# Altium schematic sheet LTC3350.SchDoc(LTC3350) (continued)

## Library data of the parts
C1 C13 C14 C18 C20 C21 = CAP 0603 100nF 50V: Ceramic Capacitor, Multilayer, Ceramic, 50V, 10% +Tol, 10% -Tol, X7R, 15% TC, 0.1uF, Surface Mount, 0603; Manufacturer: Samsung; Manufacturer Part Number: CL10B104KB8NNNC; Multilayer: Yes; Capacitor Type: Ceramic; Case/Package: 0603; Category: Ceramic Capacitors; Component Kind: Standard; ComponentLink1Description: https://datasheet.ciiva.com:443/pdfs/VipMasterIC/IC/SEMS/SEMS-S-A0008384515/SEMS-S-A0008873300-1.pdf?src-supplier=IHS+Markit; ComponentLink1URL: https://datasheet.ciiva.com:443/pdfs/VipMasterIC/IC/SEMS/SEMS-S-A0008384515/SEMS-S-A0008873300-1.pdf?src-supplier=IHS+Markit; ComponentLink2Description: https://datasheet.ciiva.com/25803/cl10b104kb8nnnc-25803393.pdf?src-supplier=Digikey; ComponentLink2URL: https://datasheet.ciiva.com/25803/cl10b104kb8nnnc-25803393.pdf?src-supplier=Digikey; ComponentLink3Description: https://datasheet.ciiva.com/26838/getdatasheetpartid-89097-26838411.pdf?src-supplier=Verical; ComponentLink3URL: https://datasheet.ciiva.com/26838/getdatasheetpartid-89097-26838411.pdf?src-supplier=Verical; ComponentLink4Description: https://datasheet.ciiva.com/29198/456651-da-01-en-vs-kondensator-0605-29198839.pdf?src-supplier=Conrad; ComponentLink4URL: https://datasheet.ciiva.com/29198/456651-da-01-en-vs-kondensator-0605-29198839.pdf?src-supplier=Conrad; Dielectric Material: Ceramic; Height: 0.9mm; JESD-609 Code: e3; Length: 1.6mm; Library Name: Symbols.SchLib; Max Operating Temperature: 125°C; Min Operating Temperature: -55°C; Mounting Technology: Surface Mount; Package Shape: Rectangular; Package Style: SMTMeter; Packaging: Tape and Reel; Pins: 2; Pricing: 1=0.1, 10=0.026, 50=0.0146, 100=0.0114, 500=0.00856, 1000=0.00672, 4000=0.00562, 8000=0.00513, 12000=0.00489, 28000=0.00464, 100000=0.00336, 200000=0.00325, 400000=0.00306 (USD); Rated DC Voltage (URdc): 50V; Reach SVHC Compliant: Yes; RoHS: Yes; RoHS Compliant: Yes; Stock: 6712361; Supplier: Digikey; Supplier Part Number: 1276-1000-1-ND; Temperature Characteristics Code: X7R; Terminal Finish: Nickel; Termination: Wraparound; Tolerance  (fill in as +-): 10%; Tolerance (fill in as +-): 10%; Value: 100nF; Width: 0.8mm
C2 C3 C9 = CAP 0805 1uF 50V: Ceramic Capacitor, Multilayer, Ceramic, 50V, 10% +Tol, 10% -Tol, X7R, 15% TC, 1uF, Surface Mount, 0805; Manufacturer: Samsung; Manufacturer Part Number: CL21B105KBFNNNG; Multilayer: Yes; Capacitor Type: Ceramic; Case/Package: 0805; Category: Ceramic Capacitors; Component Kind: Standard; ComponentLink1Description: https://datasheet.ciiva.com:443/pdfs/VipMasterIC/IC/SEMS/SEMS-S-A0008523115/SEMS-S-A0008523251-1.pdf?src-supplier=IHS+Markit; ComponentLink1URL: https://datasheet.ciiva.com:443/pdfs/VipMasterIC/IC/SEMS/SEMS-S-A0008523115/SEMS-S-A0008523251-1.pdf?src-supplier=IHS+Markit; ComponentLink2Description: https://datasheet.ciiva.com/25733/cl21b105kbfnnng-25733278.pdf?src-supplier=Digikey; ComponentLink2URL: https://datasheet.ciiva.com/25733/cl21b105kbfnnng-25733278.pdf?src-supplier=Digikey; ComponentLink3Description: https://datasheet.ciiva.com/29199/455898-da-01-en-vs-kondensator-0805-29199201.pdf?src-supplier=Conrad; ComponentLink3URL: https://datasheet.ciiva.com/29199/455898-da-01-en-vs-kondensator-0805-29199201.pdf?src-supplier=Conrad; ComponentLink4Description: https://datasheet.ciiva.com/26310/mlcc-catalogdownload2013-eng-26310125.pdf?src-supplier=Distrelec; ComponentLink4URL: https://datasheet.ciiva.com/26310/mlcc-catalogdownload2013-eng-26310125.pdf?src-supplier=Distrelec; ComponentLink5Description: https://datasheet.ciiva.com/16428/cl05b104kq5nnnc-16428872.pdf?src-supplier=Future+Electronics; ComponentLink5URL: https://datasheet.ciiva.com/16428/cl05b104kq5nnnc-16428872.pdf?src-supplier=Future+Electronics; Dielectric Material: Ceramic; Height: 1.35mm; JESD-609 Code: e3; Length: 2mm; Library Name: Symbols.SchLib; Max Operating Temperature: 125°C; Min Operating Temperature: -55°C; Mounting Technology: Surface Mount; Package Shape: Rectangular; Package Style: SMTMeter; Packaging: Tape and Reel; Pins: 2; Pricing: 1=0.11, 10=0.075, 50=0.045, 100=0.0354, 500=0.02616, 1000=0.02165, 3000=0.02015, 6000=0.01844, 9000=0.01715, 15000=0.01629, 30000=0.01543, 75000=0.01415, 150000=0.0114, 300000=0.01072 (USD); Rated DC Voltage (URdc): 50V; Reach SVHC Compliant: Yes; RoHS: Yes; RoHS Compliant: Yes; Stock: 1165093; Supplier: Digikey; Supplier Part Number: 1276-6470-1-ND; Temperature Characteristics Code: X7R; Terminal Finish: Nickel; Termination: Wraparound; Tolerance  (fill in as +-): 10%; Tolerance (fill in as +-): 10%; Value: 1uF; Width: 1.25mm
C4-C7 C10-C12 = CAP 1206 10uF 1%: Ceramic Capacitor, Multilayer, Ceramic, 50V, 10% +Tol, 10% -Tol, X7R, 15% TC, 10uF, Surface Mount, 1206; Manufacturer: Samsung; Manufacturer Part Number: CL31B106KBHNNNE; Multilayer: Yes; Capacitor Type: Ceramic; Case/Package: 1206; Category: Ceramic Capacitors; Component Kind: Standard; ComponentLink1Description: https://datasheet.ciiva.com:443/pdfs/VipMasterIC/IC/SEMS/SEMS-S-A0008524842/SEMS-S-A0008524770-1.pdf?src-supplier=IHS+Markit; ComponentLink1URL: https://datasheet.ciiva.com:443/pdfs/VipMasterIC/IC/SEMS/SEMS-S-A0008524842/SEMS-S-A0008524770-1.pdf?src-supplier=IHS+Markit; ComponentLink2Description: https://datasheet.octopart.com/CL31B106KBHNNNE-Samsung-Electro-Mechanics-datasheet-149066874.pdf?src-supplier=Future+Electronics; ComponentLink2URL: https://datasheet.octopart.com/CL31B106KBHNNNE-Samsung-Electro-Mechanics-datasheet-149066874.pdf?src-supplier=Future+Electronics; ComponentLink3Description: http://www.samsungsem.com/kr/support/product-search/mlcc/CL31B106KBHNNNE.jsp?src-supplier=Digikey; ComponentLink3URL: http://www.samsungsem.com/kr/support/product-search/mlcc/CL31B106KBHNNNE.jsp?src-supplier=Digikey; ComponentLink4Description: https://datasheet.octopart.com/CL31B106KBHNNNE-Samsung-Electro-Mechanics-datasheet-110617700.pdf?src-supplier=LCSC; ComponentLink4URL: https://datasheet.octopart.com/CL31B106KBHNNNE-Samsung-Electro-Mechanics-datasheet-110617700.pdf?src-supplier=LCSC; Dielectric Material: Ceramic; Height: 1.8mm; JESD-609 Code: e3; Length: 3.2mm; Library Name: Symbols.SchLib; Max Operating Temperature: 125°C; Min Operating Temperature: -55°C; Mounting Technology: Surface Mount; Package Shape: Rectangular; Package Style: SMTMeter; Packaging: Tape and Reel; Pins: 2; Pricing: 1=0.33, 10=0.216, 50=0.1592, 100=0.1296, 500=0.10234, 1000=0.08641 (USD); Rated DC Voltage (URdc): 50V; Reach SVHC Compliant: Yes; RoHS: Yes; RoHS Compliant: Yes; Stock: 418195; Supplier: Digikey; Supplier Part Number: 1276-6767-1-ND; Temperature Characteristics Code: X7R; Terminal Finish: Nickel; Termination: Wraparound; Tolerance  (fill in as +-): 10%; Tolerance (fill in as +-): 10%; Value: 10uF; Width: 1.6mm
C8 = CAP ELEC TH 100uF 50V: Aluminum Electrolytic Capacitor, Polarized, Aluminum (wet), 50V, 20% +Tol, 20% -Tol, 100uF, Through Hole Mount; Manufacturer: Rubycon; Manufacturer Part Number: 50ZLH100MEFC8X11.5; Manufacturer Series: ZLH; Capacitor Type: Aluminium; Ripple Current: 724mA; Category: Aluminum Electrolytic Capacitors; Component Kind: Standard; ComponentLink1Description: https://datasheet.ciiva.com:443/pdfs/VipMasterIC/IC/RUBY/RUBYS01453/RUBYS01453-1.pdf?src-supplier=IHS+Markit; ComponentLink1URL: https://datasheet.ciiva.com:443/pdfs/VipMasterIC/IC/RUBY/RUBYS01453/RUBYS01453-1.pdf?src-supplier=IHS+Markit; ComponentLink2Description: https://datasheet.ciiva.com/11782/0900766b80e2f602-11782301.pdf?src-supplier=Rs; ComponentLink2URL: https://datasheet.ciiva.com/11782/0900766b80e2f602-11782301.pdf?src-supplier=Rs; ComponentLink3Description: https://datasheet.ciiva.com/7976/1758712-7976054.pdf?src-supplier=Element14; ComponentLink3URL: https://datasheet.ciiva.com/7976/1758712-7976054.pdf?src-supplier=Element14; Diameter: 8mm; Dielectric Material: Aluminium; JESD-609 Code: e3; Length: 11.5mm; Library Name: Symbols.SchLib; Max Operating Temperature: 105°C; Min Operating Temperature: -40°C; Package Style: RadialMeter; Packaging: Bulk; Pins: 2; Polarity: Polarized; Pricing: 1=0.27, 10=0.17, 50=0.1246, 100=0.1098, 500=0.08472, 2000=0.07694, 4000=0.07038 (USD); Rated DC Voltage (URdc): 50V; Reach SVHC Compliant: Yes; RoHS: Yes; RoHS Compliant: Yes; Stock: 274558; Supplier: Digikey; Supplier Part Number: 1189-2327-ND; Terminal Finish: Tin; Terminal Pitch: 3.5mm; Tolerance  (fill in as +-): 20%; Tolerance (fill in as +-): 20%; Value: 100uF
C15 C16 C23 = Cap 0603 1uF 16V: Ceramic Capacitor, Multilayer, Ceramic, 16V, 10% +Tol, 10% -Tol, X7R, 15% TC, 1uF, Surface Mount, 0603; Manufacturer: Yageo; Manufacturer Part Number: CC0603KRX7R7BB105; Multilayer: Yes; Capacitor Type: Ceramic; Case/Package: 0603; Category: Ceramic Capacitors; Component Kind: Standard; ComponentLink1Description: https://datasheet.ciiva.com:443/pdfs/VipMasterIC/IC/YAGO/YAGO-S-A0003557223/YAGO-S-A0003557223-1.pdf?src-supplier=IHS+Markit; ComponentLink1URL: https://datasheet.ciiva.com:443/pdfs/VipMasterIC/IC/YAGO/YAGO-S-A0003557223/YAGO-S-A0003557223-1.pdf?src-supplier=IHS+Markit; ComponentLink2Description: https://datasheet.ciiva.com/8033/1734573-8033801.pdf?src-supplier=Element14; ComponentLink2URL: https://datasheet.ciiva.com/8033/1734573-8033801.pdf?src-supplier=Element14; ComponentLink3Description: https://datasheet.ciiva.com/8033/1734573-8033800.pdf?src-supplier=Element14; ComponentLink3URL: https://datasheet.ciiva.com/8033/1734573-8033800.pdf?src-supplier=Element14; ComponentLink4Description: https://datasheet.ciiva.com/7978/1489800-7978143.pdf?src-supplier=Element14; ComponentLink4URL: https://datasheet.ciiva.com/7978/1489800-7978143.pdf?src-supplier=Element14; ComponentLink5Description: https://datasheet.ciiva.com/28450/1489800-28450557.pdf?src-supplier=Element14; ComponentLink5URL: https://datasheet.ciiva.com/28450/1489800-28450557.pdf?src-supplier=Element14; ComponentLink6Description: https://datasheet.ciiva.com/176/mlccorderingcode-176789.pdf?src-supplier=Digikey; ComponentLink6URL: https://datasheet.ciiva.com/176/mlccorderingcode-176789.pdf?src-supplier=Digikey; ComponentLink7Description: https://datasheet.ciiva.com/176/mlccorderingcode-176788.pdf?src-supplier=Digikey; ComponentLink7URL: https://datasheet.ciiva.com/176/mlccorderingcode-176788.pdf?src-supplier=Digikey; ComponentLink8Description: https://datasheet.ciiva.com/176/mlccorderingcode-176790.pdf?src-supplier=Digikey; ComponentLink8URL: https://datasheet.ciiva.com/176/mlccorderingcode-176790.pdf?src-supplier=Digikey; ComponentLink9Description: https://datasheet.ciiva.com/5425/upy-gphc-x7r-6-3v-to-50v-8-12304-5425495.pdf?src-supplier=Mouser; ComponentLink9URL: https://datasheet.ciiva.com/5425/upy-gphc-x7r-6-3v-to-50v-8-12304-5425495.pdf?src-supplier=Mouser; Dielectric Material: Ceramic; Height: 0.8mm; JESD-609 Code: e3; Length: 1.6mm; Library Name: Symbols.SchLib; Max Operating Temperature: 125°C; Min Operating Temperature: -55°C; Mounting Technology: Surface Mount; Package Shape: Rectangular; Package Style: SMTMeter; Packaging: Tape and Reel; Pins: 2; Pricing: 1=0.1, 10=0.066, 50=0.0392, 100=0.0308, 500=0.02274, 1000=0.01882, 4000=0.01603, 8000=0.01491, 12000=0.01416, 28000=0.01342, 100000=0.01313 (USD); Rated DC Voltage (URdc): 16V; Reach SVHC Compliant: Yes; RoHS: Yes; RoHS Compliant: Yes; Stock: 9253411; Supplier: Digikey; Supplier Part Number: 311-1446-1-ND; Temperature Characteristics Code: X7R; Terminal Finish: Nickel; Termination: Wraparound; Tolerance  (fill in as +-): 10%; Tolerance (fill in as +-): 10%; Value: 1uF; Width: 0.8mm
C17 = CAP 0603 100pF 50V: Ceramic Capacitor, Multilayer, Ceramic, 50V, 5% +Tol, 5% -Tol, C0G, -/+30ppm/Cel TC, 0.0001uF, Surface Mount, 0603; Manufacturer: Samsung; Manufacturer Part Number: CL10C101JB8NNNC; Multilayer: Yes; Capacitor Type: Ceramic; Case/Package: 0603; Category: Ceramic Capacitors; Component Kind: Standard; ComponentLink1Description: https://datasheet.ciiva.com:443/pdfs/VipMasterIC/IC/SEMS/SEMS-S-A0008521219/SEMS-S-A0008521199-1.pdf?src-supplier=IHS+Markit; ComponentLink1URL: https://datasheet.ciiva.com:443/pdfs/VipMasterIC/IC/SEMS/SEMS-S-A0008521219/SEMS-S-A0008521199-1.pdf?src-supplier=IHS+Markit; ComponentLink2Description: https://datasheet.ciiva.com/25782/cl10c101jb8nnnc-25782145.pdf?src-supplier=Digikey; ComponentLink2URL: https://datasheet.ciiva.com/25782/cl10c101jb8nnnc-25782145.pdf?src-supplier=Digikey; ComponentLink3Description: https://datasheet.ciiva.com/26838/getdatasheetpartid-358356-26838483.pdf?src-supplier=Verical; ComponentLink3URL: https://datasheet.ciiva.com/26838/getdatasheetpartid-358356-26838483.pdf?src-supplier=Verical; ComponentLink4Description: https://datasheet.ciiva.com/29199/456574-da-01-en-vs-kondensator-0603-29199002.pdf?src-supplier=Conrad; ComponentLink4URL: https://datasheet.ciiva.com/29199/456574-da-01-en-vs-kondensator-0603-29199002.pdf?src-supplier=Conrad; ComponentLink5Description: https://datasheet.ciiva.com/26310/mlcc-catalogdownload2013-eng-26310303.pdf?src-supplier=Distrelec; ComponentLink5URL: https://datasheet.ciiva.com/26310/mlcc-catalogdownload2013-eng-26310303.pdf?src-supplier=Distrelec; Dielectric Material: Ceramic; Height: 0.9mm; JESD-609 Code: e3; Length: 1.6mm; Library Name: Symbols.SchLib; Max Operating Temperature: 125°C; Min Operating Temperature: -55°C; Mounting Technology: Surface Mount; Package Shape: Rectangular; Package Style: SMTMeter; Packaging: Tape and Reel; Pins: 2; Pricing: 1=0.1, 10=0.026, 50=0.015, 100=0.0116, 500=0.00874, 1000=0.00686 (USD); Rated DC Voltage (URdc): 50V; Reach SVHC Compliant: Yes; RoHS: Yes; RoHS Compliant: Yes; Stock: 663344; Supplier: Digikey; Supplier Part Number: 1276-1008-1-ND; Temperature Characteristics Code: C0G; Terminal Finish: Nickel; Termination: Wraparound; Tolerance  (fill in as +-): 5%; Tolerance (fill in as +-): 5%; Value: 100pF; Width: 0.8mm
C19 C22 C24 C26 = CAP SUPER 40F 3V: Cap 40F 3.0/2.7V T/h; Manufacturer: Tecate Group; Manufacturer Part Number: TPLH-3R0/40WR12X46; Capacitance: 40F; Component Kind: Standard; Library Name: Symbols.SchLib; Pricing: 1=4.07, 10=3.496, 50=3.1708, 100=2.618, 500=2.27654, 1000=2.11393, 2500=2.07328 (USD); Stock: 517; Supplier: DigiKey; Supplier Part Number: 2085-TPLH-3R0/40WR12X46-ND
C25 = CAP 0603 1nF 50V: Ceramic Capacitor, Multilayer, Ceramic, 50V, 10% +Tol, 10% -Tol, X7R, 15% TC, 0.001uF, Surface Mount, 0603; Manufacturer: Samsung; Manufacturer Part Number: CL10B102KB8NNNC; Multilayer: Yes; Capacitor Type: Ceramic; Case/Package: 0603; Category: Ceramic Capacitors; Component Kind: Standard; ComponentLink1Description: https://datasheet.ciiva.com:443/pdfs/VipMasterIC/IC/SEMS/SEMS-S-A0008529100/SEMS-S-A0008529114-1.pdf?src-supplier=IHS+Markit; ComponentLink1URL: https://datasheet.ciiva.com:443/pdfs/VipMasterIC/IC/SEMS/SEMS-S-A0008529100/SEMS-S-A0008529114-1.pdf?src-supplier=IHS+Markit; ComponentLink2Description: https://datasheet.ciiva.com/25805/cl10b102kb8nnnc-25805137.pdf?src-supplier=Digikey; ComponentLink2URL: https://datasheet.ciiva.com/25805/cl10b102kb8nnnc-25805137.pdf?src-supplier=Digikey; ComponentLink3Description: https://datasheet.ciiva.com/15216/getdatasheetpartid-359690-15216231.pdf?src-supplier=Verical; ComponentLink3URL: https://datasheet.ciiva.com/15216/getdatasheetpartid-359690-15216231.pdf?src-supplier=Verical; ComponentLink4Description: https://datasheet.ciiva.com/29198/458300-da-01-en-vs-kondensator-0603-29198498.pdf?src-supplier=Conrad; ComponentLink4URL: https://datasheet.ciiva.com/29198/458300-da-01-en-vs-kondensator-0603-29198498.pdf?src-supplier=Conrad; ComponentLink5Description: https://datasheet.ciiva.com/26310/mlcc-catalogdownload2013-eng-26310326.pdf?src-supplier=Distrelec; ComponentLink5URL: https://datasheet.ciiva.com/26310/mlcc-catalogdownload2013-eng-26310326.pdf?src-supplier=Distrelec; ComponentLink6Description: https://datasheet.ciiva.com/16199/cl05b104kq5nnnc-16199064.pdf?src-supplier=Future+Electronics; ComponentLink6URL: https://datasheet.ciiva.com/16199/cl05b104kq5nnnc-16199064.pdf?src-supplier=Future+Electronics; Dielectric Material: Ceramic; Height: 0.9mm; JESD-609 Code: e3; Length: 1.6mm; Library Name: Symbols.SchLib; Max Operating Temperature: 125°C; Min Operating Temperature: -55°C; Mounting Technology: Surface Mount; Package Shape: Rectangular; Package Style: SMTMeter; Packaging: Tape and Reel; Pins: 2; Pricing: 1=0.1, 10=0.027, 50=0.0156, 100=0.0122, 500=0.00916, 1000=0.00719, 4000=0.00602, 8000=0.00549, 12000=0.00523, 28000=0.00497, 100000=0.0036, 200000=0.00348, 400000=0.00327 (USD); Rated DC Voltage (URdc): 50V; Reach SVHC Compliant: Yes; RoHS: Yes; RoHS Compliant: Yes; Stock: 433576; Supplier: Digikey; Supplier Part Number: 1276-1018-1-ND; Temperature Characteristics Code: X7R; Terminal Finish: Nickel; Termination: Wraparound; Tolerance  (fill in as +-): 10%; Tolerance (fill in as +-): 10%; Value: 1nF; Width: 0.8mm
D1 = B0540WS-7: Rectifier Diode, Schottky, 1 Phase, 1 Element, 0.5A, 40V V(RRM), Silicon; Manufacturer: Diodes; Additional Feature: UL RECOGNIZED; Forward Voltage: 300mV; Max Output current: 500mA; Max Power Dissipation: 235mW; Non-rep Pk Forward Current-Max: 3A; Number of Elements: 1; Package Body Material: Plastic; Qualification Status: Not Qualified; Time @ Peak Reflow Temperature-Max (s): 40s; Category: LDO; Component Kind: Standard; ComponentLink1Description: https://datasheet.ciiva.com:443/pdfs/VipMasterIC/IC/DIOD/DIOD-S-A0004145132/DIOD-S-A0004145132-1.pdf?src-supplier=IHS+Markit; ComponentLink1URL: https://datasheet.ciiva.com:443/pdfs/VipMasterIC/IC/DIOD/DIOD-S-A0004145132/DIOD-S-A0004145132-1.pdf?src-supplier=IHS+Markit; ComponentLink2Description: https://datasheet.ciiva.com/8300/ds30524-8300469.pdf?src-supplier=Avnet; ComponentLink2URL: https://datasheet.ciiva.com/8300/ds30524-8300469.pdf?src-supplier=Avnet; ComponentLink3Description: https://datasheet.ciiva.com/1177/ds30524-1177377.pdf?src-supplier=Element14; ComponentLink3URL: https://datasheet.ciiva.com/1177/ds30524-1177377.pdf?src-supplier=Element14; ComponentLink4Description: https://datasheet.ciiva.com/4715/ds30524-63250-4715615.pdf?src-supplier=Mouser; ComponentLink4URL: https://datasheet.ciiva.com/4715/ds30524-63250-4715615.pdf?src-supplier=Mouser; ComponentLink5Description: https://datasheet.ciiva.com/34303/2014916-34303853.pdf?src-supplier=Jameco; ComponentLink5URL: https://datasheet.ciiva.com/34303/2014916-34303853.pdf?src-supplier=Jameco; ComponentLink6Description: https://datasheet.ciiva.com/912/ds30524-912535.pdf?src-supplier=Digikey; ComponentLink6URL: https://datasheet.ciiva.com/912/ds30524-912535.pdf?src-supplier=Digikey; ComponentLink7Description: https://datasheet.ciiva.com/912/ds30524-912536.pdf?src-supplier=Digikey; ComponentLink7URL: https://datasheet.ciiva.com/912/ds30524-912536.pdf?src-supplier=Digikey; ComponentLink8Description: https://datasheet.ciiva.com/912/ds30524-912537.pdf?src-supplier=Digikey; ComponentLink8URL: https://datasheet.ciiva.com/912/ds30524-912537.pdf?src-supplier=Digikey; Diode Element Material: SILICON; Diode Type: RECTIFIER; JESD-30 Code: R-PDSO-G2; JESD-609 Code: e3; Library Name: Symbols.SchLib; Manufacturer Part Number: B0540WS-7; Max Operating Temperature: 125°C; Min Operating Temperature: -40°C; Moisture Sensitivity Level: 1; Package Shape: Rectangular; Package Style: SMALL OUTLINEMeter; Peak Reflow Temperature (Cel): 260°C; Pins: 2; Pricing: 1=0.34, 10=0.209, 100=0.1313, 500=0.09736, 1000=0.08638 (USD); Reach SVHC Compliant: Yes; Rep Pk Reverse Voltage-Max: 40V; RoHS: Yes; RoHS Compliant: Yes; Stock: 71399; Supplier: Digikey; Supplier Part Number: B0540WSDICT-ND; Surface Mount: Yes; Technology: SCHOTTKY; Terminal Finish: Tin; Terminal Form: Gull Wing; Terminal Position: DUAL
D2-D4 = LED 0805 RED: Single Color LED, Red, Water Clear, 1.4mm; Manufacturer: Wurth Electronics; Manufacturer Part Number: 150080RS75000; Channels: 1; Forward Current: 30mA; Luminous Intensity-Nom: 150mcd; Overall Height: 0.7mm; Shape: Rectangular; Viewing Angle: 140°; Category: LEDs; Color: Red; Component Kind: Standard; ComponentLink1Description: https://datasheet.ciiva.com:443/pdfs/VipMasterIC/IC/MIDC/MIDC-S-A0007455974/MIDC-S-A0007455974-1.pdf?src-supplier=IHS+Markit; ComponentLink1URL: https://datasheet.ciiva.com:443/pdfs/VipMasterIC/IC/MIDC/MIDC-S-A0007455974/MIDC-S-A0007455974-1.pdf?src-supplier=IHS+Markit; ComponentLink2Description: https://datasheet.ciiva.com/22656/150080rs75000-22656961.pdf?src-supplier=Element14; ComponentLink2URL: https://datasheet.ciiva.com/22656/150080rs75000-22656961.pdf?src-supplier=Element14; ComponentLink3Description: https://datasheet.ciiva.com/29279/759435-da-01-en-chipled-0805-w-kl-rot-150080rs75000-29279032.pdf?src-supplier=Conrad; ComponentLink3URL: https://datasheet.ciiva.com/29279/759435-da-01-en-chipled-0805-w-kl-rot-150080rs75000-29279032.pdf?src-supplier=Conrad; Lens Style: WATER CLEAR; Library Name: Symbols.SchLib; Max Operating Temperature: 85°C; Min Operating Temperature: -40°C; Mounting Technology: Surface Mount; Optoelectronic Device Type: SINGLE COLOR LED; Packaging: Tape and Reel; Pins: 2; Pricing: 1=0.18, 50=0.176, 100=0.151, 500=0.131 (USD); Reach SVHC Compliant: Yes; RoHS: Yes; RoHS Compliant: Yes; Size: 1.4mm; Stock: 54770; Supplier: Digikey; Supplier Part Number: 732-4984-1-ND; Terminal Pitch: 2.1mm
D5-D7 = LED 0805 Emerald: Single Color LED, Red, Water Clear, 1.4mm; Manufacturer: Wurth Electronics; Manufacturer Part Number: 150080GS75000; Channels: 1; Color: Green; Forward Current: 30mA; Luminous Intensity-Nom: 450mcd; Overall Height: 0.7mm; Shape: Rectangular; Viewing Angle: 140°; Category: LEDs; Component Kind: Standard; ComponentLink1Description: https://datasheet.ciiva.com:443/pdfs/VipMasterIC/IC/MIDC/MIDC-S-A0007455966/MIDC-S-A0007455966-1.pdf?src-supplier=IHS+Markit; ComponentLink1URL: https://datasheet.ciiva.com:443/pdfs/VipMasterIC/IC/MIDC/MIDC-S-A0007455966/MIDC-S-A0007455966-1.pdf?src-supplier=IHS+Markit; ComponentLink2Description: https://datasheet.ciiva.com/29563/150080gs75000-29563008.pdf?src-supplier=Digikey; ComponentLink2URL: https://datasheet.ciiva.com/29563/150080gs75000-29563008.pdf?src-supplier=Digikey; ComponentLink3Description: https://datasheet.ciiva.com/29279/759434-da-01-en-chipled-0805-w-kl-gruen-150080gs75000-29279039.pdf?src-supplier=Conrad; ComponentLink3URL: https://datasheet.ciiva.com/29279/759434-da-01-en-chipled-0805-w-kl-gruen-150080gs75000-29279039.pdf?src-supplier=Conrad; ComponentLink4Description: https://datasheet.ciiva.com:443/pdfs/VipMasterIC/IC/MIDC/MIDC-S-A0007455974/MIDC-S-A0007455974-1.pdf?src-supplier=IHS+Markit; ComponentLink4URL: https://datasheet.ciiva.com:443/pdfs/VipMasterIC/IC/MIDC/MIDC-S-A0007455974/MIDC-S-A0007455974-1.pdf?src-supplier=IHS+Markit; ComponentLink5Description: https://datasheet.ciiva.com/22656/150080rs75000-22656961.pdf?src-supplier=Element14; ComponentLink5URL: https://datasheet.ciiva.com/22656/150080rs75000-22656961.pdf?src-supplier=Element14; ComponentLink6Description: https://datasheet.ciiva.com/29563/150080gs75000-29563008.pdf?src-supplier=Digikey; ComponentLink6URL: https://datasheet.ciiva.com/29563/150080gs75000-29563008.pdf?src-supplier=Digikey; ComponentLink7Description: https://datasheet.ciiva.com/29279/759434-da-01-en-chipled-0805-w-kl-gruen-150080gs75000-29279039.pdf?src-supplier=Conrad; ComponentLink7URL: https://datasheet.ciiva.com/29279/759434-da-01-en-chipled-0805-w-kl-gruen-150080gs75000-29279039.pdf?src-supplier=Conrad; ComponentLink8Description: https://datasheet.ciiva.com/29279/759435-da-01-en-chipled-0805-w-kl-rot-150080rs75000-29279032.pdf?src-supplier=Conrad; ComponentLink8URL: https://datasheet.ciiva.com/29279/759435-da-01-en-chipled-0805-w-kl-rot-150080rs75000-29279032.pdf?src-supplier=Conrad; Lens Style: WATER CLEAR; Library Name: Symbols.SchLib; Max Operating Temperature: 85°C; Min Operating Temperature: -40°C; Mounting Technology: Surface Mount; Optoelectronic Device Type: SINGLE COLOR LED; Packaging: Tape and Reel; Pins: 2; Pricing: 1=0.18, 50=0.176, 100=0.151, 500=0.131 (USD); Reach SVHC Compliant: Yes; RoHS: Yes; RoHS Compliant: Yes; Size: 1.4mm; Stock: 59279; Supplier: Digikey; Supplier Part Number: 732-4983-1-ND; Terminal Pitch: 2.1mm
J1 J2 = DC_Socket: Conn Jack R/a Pcb 3.5X1.35MM; Manufacturer: Tensility; Manufacturer Part Number: 54-00168; Category: Connectors; Component Kind: Standard; Library Name: Symbols.SchLib; Pricing: 1=0.68, 10=0.592, 25=0.5412, 40=0.5095, 80=0.48825, 230=0.44578, 500=0.42456, 1000=0.35026, 2500=0.31842 (USD); Stock: 4630; Supplier: Digikey; Supplier Part Number: 839-54-00168-ND
J3 = Jumper 3P: Component Kind: Standard; Library Name: Symbols.SchLib
L1 = IND 1210 27uH 20%: General Purpose Inductor, 27uH, 20%, 1 Element, Ferrite-Core, SMD, 4747; Manufacturer: Bourns; Manufacturer Part Number: SRR1210-270M; Case/Size Code: 4747; Channels: 1; DC Resistance (DCR): 40mR; Inductor Application: POWER INDUCTOR; Max Current Rating: 4.2A; Package Height: 10mm; Package Length: 12mm; Quality Factor-Min (at L-nom): 16; Self Resonant Frequency: 6.5MHz; Shape/Size Description: RECTANGULAR PACKAGE; Shielding: Shielded; Test Frequency: 2.52MHz; Category: Fixed Inductors; Component Kind: Standard; ComponentLink1Description: https://datasheet.ciiva.com:443/pdfs/VipMasterIC/IC/BOUR/BOUR-S-A0006601741/BOUR-S-A0006601741-1.pdf?src-supplier=IHS+Markit; ComponentLink1URL: https://datasheet.ciiva.com:443/pdfs/VipMasterIC/IC/BOUR/BOUR-S-A0006601741/BOUR-S-A0006601741-1.pdf?src-supplier=IHS+Markit; ComponentLink2Description: https://datasheet.ciiva.com/1060/srr1210-1060297.pdf?src-supplier=Digikey; ComponentLink2URL: https://datasheet.ciiva.com/1060/srr1210-1060297.pdf?src-supplier=Digikey; ComponentLink3Description: https://datasheet.ciiva.com/1060/srr1210-1060298.pdf?src-supplier=Digikey; ComponentLink3URL: https://datasheet.ciiva.com/1060/srr1210-1060298.pdf?src-supplier=Digikey; ComponentLink4Description: https://datasheet.ciiva.com/6704/srr1210-6704169.pdf?src-supplier=Digikey; ComponentLink4URL: https://datasheet.ciiva.com/6704/srr1210-6704169.pdf?src-supplier=Digikey; ComponentLink5Description: https://datasheet.ciiva.com/48685/srr1210-48685080.pdf?src-supplier=Avnet; ComponentLink5URL: https://datasheet.ciiva.com/48685/srr1210-48685080.pdf?src-supplier=Avnet; ComponentLink6Description: https://datasheet.ciiva.com/30207/srr1210-30207629.pdf?src-supplier=Onlinecomponents.com; ComponentLink6URL: https://datasheet.ciiva.com/30207/srr1210-30207629.pdf?src-supplier=Onlinecomponents.com; ComponentLink7Description: https://datasheet.ciiva.com/7849/1430851-7849765.pdf?src-supplier=Element14; ComponentLink7URL: https://datasheet.ciiva.com/7849/1430851-7849765.pdf?src-supplier=Element14; Construction: Shielded; Core Material: Ferrite; Inductance-Nom (L): 27uH; Inductor Type: GENERAL PURPOSE INDUCTOR; JESD-609 Code: e3; Library Name: Symbols.SchLib; Max Operating Temperature: 125°C; Min Operating Temperature: -40°C; Package Style: SMTMeter; Package Width: 12mm; Packaging: Tape and Reel; Pins: 2; Pricing: 1=1.26, 10=1.064, 25=0.9676, 50=0.9096, 100=0.7547, 250=0.69664, 500=0.65796, 750=0.61925, 1250=0.5999, 2500=0.58055, 6250=0.5612, 12500=0.54184 (USD); Reach SVHC Compliant: Yes; RoHS: Yes; RoHS Compliant: Yes; Stock: 3143; Supplier: Digikey; Supplier Part Number: SRR1210-270MCT-ND; Surface Mount: Yes; Terminal Finish: Nickel; Terminal Placement: Dual Ended; Termination: Wraparound; Tolerance: 20%
Q1-Q3 = SIS434DN-T1-GE3: Power Field-Effect Transistor, 17.6A I(D), 40V, 0.0076ohm, 1-Element, N-Channel, Silicon, Metal-oxide Semiconductor FET; Manufacturer: Vishay Siliconix; Avalanche Energy Rating (Eas): 45mJ; Case Connection: DRAIN; Drain Current-Max (Abs) (ID): 35A; Drain-source On Resistance-Max: 7.6mR; Number of Elements: 1; Operating Mode: ENHANCEMENT MODE; Package Body Material: Plastic; Power Dissipation-Max (Abs): 52W; Pulsed Drain Current-Max (IDM): 60A; Qualification Status: Not Qualified; Time @ Peak Reflow Temperature-Max (s): 40s; Category: MOSFETs; Component Kind: Standard; ComponentLink1Description: https://datasheet.ciiva.com:443/pdfs/VipMasterIC/IC/VISH/VISH-S-A0001113177/VISH-S-A0001113177-1.pdf?src-supplier=IHS+Markit; ComponentLink1URL: https://datasheet.ciiva.com:443/pdfs/VipMasterIC/IC/VISH/VISH-S-A0001113177/VISH-S-A0001113177-1.pdf?src-supplier=IHS+Markit; ComponentLink2Description: https://datasheet.ciiva.com/7710/1750669-7710578.pdf?src-supplier=Element14; ComponentLink2URL: https://datasheet.ciiva.com/7710/1750669-7710578.pdf?src-supplier=Element14; ComponentLink3Description: https://datasheet.ciiva.com/13058/sis434dn-244447-13058819.pdf?src-supplier=Mouser; ComponentLink3URL: https://datasheet.ciiva.com/13058/sis434dn-244447-13058819.pdf?src-supplier=Mouser; ComponentLink4Description: https://datasheet.ciiva.com/6376/sis434dn-6376113.pdf?src-supplier=Digikey; ComponentLink4URL: https://datasheet.ciiva.com/6376/sis434dn-6376113.pdf?src-supplier=Digikey; ComponentLink5Description: https://datasheet.ciiva.com/7710/sis434dn-7710359.pdf?src-supplier=Element14; ComponentLink5URL: https://datasheet.ciiva.com/7710/sis434dn-7710359.pdf?src-supplier=Element14; ComponentLink6Description: https://datasheet.ciiva.com/16217/sis434dn-16217532.pdf?src-supplier=Future+Electronics; ComponentLink6URL: https://datasheet.ciiva.com/16217/sis434dn-16217532.pdf?src-supplier=Future+Electronics; ComponentLink7Description: https://datasheet.ciiva.com/6376/sis434dn-6376110.pdf?src-supplier=Digikey; ComponentLink7URL: https://datasheet.ciiva.com/6376/sis434dn-6376110.pdf?src-supplier=Digikey; Drain Current-Max (ID): 17.6A; DS Breakdown Voltage-Min: 40V; FET Technology: METAL-OXIDE SEMICONDUCTOR; JESD-609 Code: e3; Library Name: Symbols.SchLib; Manufacturer Part Number: SIS434DN-T1-GE3; Max Operating Temperature: 150°C; Moisture Sensitivity Level: 1; Package Shape: Square; Package Style: SMALL OUTLINEMeter; Peak Reflow Temperature (Cel): 250°C; Pins: 5; Polarity/Channel Type: N-CHANNEL; Pricing: 3000=0.38602, 6000=0.36764, 9000=0.35067 (USD); Reach SVHC Compliant: No; RoHS: Yes; RoHS Compliant: Yes; Stock: 17500; Supplier: Digikey; Supplier Part Number: SIS434DN-T1-GE3TR-ND; Surface Mount: Yes; Terminal Finish: Tin; Terminal Form: C BEND; Terminal Position: DUAL; Transistor Element Material: SILICON
R1 = RES 1206 WIDE 30mR 1%: Fixed Resistor, Thin Film, 1W, 0.03ohm, 1% +/-Tol, -100,100ppm/Cel, 1206; Manufacturer: Susumu; Manufacturer Part Number: PRL1632-R030-F-T1; Manufacturer Series: PRL1632(FTOL); Package Height: 0.5mm; Package Length: 3.2mm; Package Width: 1.6mm; Temperature Coefficient: -100100ppm/°C; Case/Package: 1206; Category: Chip SMD Resistors; Component Kind: Standard; ComponentLink1Description: https://datasheet.ciiva.com:443/pdfs/VipMasterIC/IC/SUSU/SUSUD00053/SUSUD00053-1.pdf?src-supplier=IHS+Markit; ComponentLink1URL: https://datasheet.ciiva.com:443/pdfs/VipMasterIC/IC/SUSU/SUSUD00053/SUSUD00053-1.pdf?src-supplier=IHS+Markit; ComponentLink2Description: https://datasheet.ciiva.com/2504/prl-rl-data-sheet-2504442.pdf?src-supplier=Digikey; ComponentLink2URL: https://datasheet.ciiva.com/2504/prl-rl-data-sheet-2504442.pdf?src-supplier=Digikey; ComponentLink3Description: https://datasheet.ciiva.com/2504/prl-rl-data-sheet-2504443.pdf?src-supplier=Digikey; ComponentLink3URL: https://datasheet.ciiva.com/2504/prl-rl-data-sheet-2504443.pdf?src-supplier=Digikey; ComponentLink4Description: https://datasheet.ciiva.com/2504/prl-rl-data-sheet-2504444.pdf?src-supplier=Digikey; ComponentLink4URL: https://datasheet.ciiva.com/2504/prl-rl-data-sheet-2504444.pdf?src-supplier=Digikey; Construction: Chip; JESD-609 Code: e3; Library Name: Symbols.SchLib; Package Style: SMTMeter; Packaging: Tape and Reel; Pins: 2; Power: 1W; Pricing: 1=0.61, 10=0.485, 25=0.438, 50=0.3942, 100=0.3256, 250=0.3066, 500=0.2482 (USD); Reach SVHC Compliant: Yes; Resistance: 30mR; Resistor Type: FIXED RESISTOR; RoHS: Yes; RoHS Compliant: Yes; Series: PRL1632(F TOL); Stock: 3665; Supplier: Digikey; Supplier Part Number: PRL1632.030FCT-ND; Technology: THIN FILM; Terminal Finish: Tin; Tolerance: 1%; Value: 30mR
R2 = RES 0603 820kR 1%: Fixed Resistor, Metal Glaze/thick Film, 0.1W, 820000ohm, 75V, 1% +/-Tol, 100ppm/Cel, Surface Mount, 0603; Manufacturer: Yageo; Manufacturer Part Number: RC0603FR-07820KL; Additional Feature: LASER TRIMMABLE; Package Height: 0.45mm; Package Length: 1.6mm; Package Width: 0.8mm; Power: 100mW; Rated Temperature: 70°C; Temperature Coefficient: 100ppm/°C; Case/Package: 0603; Category: Chip SMD Resistors; Component Kind: Standard; ComponentLink1Description: https://datasheet.ciiva.com:443/pdfs/VipMasterIC/IC/YAGO/YAGO-S-A0008145938/YAGO-S-A0008145938-1.pdf?src-supplier=IHS+Markit; ComponentLink1URL: https://datasheet.ciiva.com:443/pdfs/VipMasterIC/IC/YAGO/YAGO-S-A0008145938/YAGO-S-A0008145938-1.pdf?src-supplier=IHS+Markit; ComponentLink2Description: http://www.mouser.com/ds/2/447/RC0603-272842.pdf?src-supplier=Mouser; ComponentLink2URL: http://www.mouser.com/ds/2/447/RC0603-272842.pdf?src-supplier=Mouser; ComponentLink3Description: http://www.farnell.com/datasheets/1868787.pdf?src-supplier=Farnell; ComponentLink3URL: http://www.farnell.com/datasheets/1868787.pdf?src-supplier=Farnell; ComponentLink4Description: https://www.verical.com/server-webapp/html/getDatasheet?partID=323822&src-supplier=Verical; ComponentLink4URL: https://www.verical.com/server-webapp/html/getDatasheet?partID=323822&src-supplier=Verical; ComponentLink5Description: https://datasheet.octopart.com/RC0603FR-07820KL-Yageo-datasheet-8328172.pdf?src-supplier=Newark; ComponentLink5URL: https://datasheet.octopart.com/RC0603FR-07820KL-Yageo-datasheet-8328172.pdf?src-supplier=Newark; ComponentLink6Description: https://datasheet.octopart.com/RC0603FR-07820KL-Yageo-datasheet-164759695.pdf?src-supplier=Future+Electronics; ComponentLink6URL: https://datasheet.octopart.com/RC0603FR-07820KL-Yageo-datasheet-164759695.pdf?src-supplier=Future+Electronics; ComponentLink7Description: http://www.farnell.com/datasheets/1868787.pdf?src-supplier=Element14; ComponentLink7URL: http://www.farnell.com/datasheets/1868787.pdf?src-supplier=Element14; ComponentLink8Description: http://www.farnell.com/datasheets/2167052.pdf?src-supplier=Element14; ComponentLink8URL: http://www.farnell.com/datasheets/2167052.pdf?src-supplier=Element14; ComponentLink9Description: http://www.farnell.com/datasheets/443971.pdf?src-supplier=Element14; ComponentLink9URL: http://www.farnell.com/datasheets/443971.pdf?src-supplier=Element14; ComponentLink10Description: http://www.yageo.com/documents/recent/PYu-RC_Group_51_RoHS_L_9.pdf?src-supplier=Digikey; ComponentLink10URL: http://www.yageo.com/documents/recent/PYu-RC_Group_51_RoHS_L_9.pdf?src-supplier=Digikey; ComponentLink11Description: http://www.farnell.com/datasheets/1868787.pdf?src-supplier=Newark; ComponentLink11URL: http://www.farnell.com/datasheets/1868787.pdf?src-supplier=Newark; ComponentLink12Description: http://www.farnell.com/datasheets/2167052.pdf?src-supplier=Newark; ComponentLink12URL: http://www.farnell.com/datasheets/2167052.pdf?src-supplier=Newark; ComponentLink13Description: https://datasheet.octopart.com/RC0603FR-07820KL-Yageo-datasheet-148775077.pdf?src-supplier=TME; ComponentLink13URL: https://datasheet.octopart.com/RC0603FR-07820KL-Yageo-datasheet-148775077.pdf?src-supplier=TME; Construction: Chip; JESD-609 Code: e3; Library Name: Symbols.SchLib; Max Operating Temperature: 155°C; Min Operating Temperature: -55°C; Mounting Technology: Surface Mount; Package Shape: Rectangular; Package Style: SMTMeter; Packaging: Tape and Reel; Pins: 2; Pricing: 5000=0.00368, 10000=0.00325, 15000=0.00303, 25000=0.0028, 35000=0.00266, 50000=0.00253, 125000=0.00225, 250000=0.00208, 500000=0.00194 (USD); Reach SVHC Compliant: Yes; Resistor Type: FIXED RESISTOR; RoHS: Yes; RoHS Compliant: Yes; Stock: 115000; Supplier: Digikey; Supplier Part Number: 311-820KHRTR-ND; Technology: METAL GLAZE/THICK FILM; Terminal Finish: Nickel; Termination: Wraparound; Tolerance: 1%; Value: 820kR; Working Voltage: 75V
R3 = RES 0603 910k 1%: Fixed Resistor, Metal Glaze/thick Film, 0.1W, 910000ohm, 75V, 1% +/-Tol, 100ppm/Cel, Surface Mount, 0603; Manufacturer: Stackpole Electronics; Manufacturer Part Number: RMCF0603FT910K; Package Height: 0.45mm; Package Length: 1.55mm; Package Width: 0.8mm; Power: 100mW; Rated Temperature: 70°C; Reference Standard: AEC-Q200; Temperature Coefficient: 100ppm/°C; Value: 910kR; Case/Package: 0603; Category: Chip SMD Resistors; Component Kind: Standard; ComponentLink1Description: https://datasheet.ciiva.com:443/pdfs/VipMasterIC/IC/SEIE/SEIE-S-A0009541969/SEIE-S-A0009541969-1.pdf?src-supplier=IHS+Markit; ComponentLink1URL: https://datasheet.ciiva.com:443/pdfs/VipMasterIC/IC/SEIE/SEIE-S-A0009541969/SEIE-S-A0009541969-1.pdf?src-supplier=IHS+Markit; ComponentLink2Description: http://components.arrow.com/part/dataview/46929517S8688437N1174?src-supplier=Arrow+Electronics; ComponentLink2URL: http://components.arrow.com/part/dataview/46929517S8688437N1174?src-supplier=Arrow+Electronics; ComponentLink3Description: https://www.seielect.com/catalog/SEI-Packaging.pdf?src-supplier=Digikey; ComponentLink3URL: https://www.seielect.com/catalog/SEI-Packaging.pdf?src-supplier=Digikey; ComponentLink4Description: https://www.seielect.com/catalog/SEI-RMCF_RMCP.pdf?src-supplier=Avnet; ComponentLink4URL: https://www.seielect.com/catalog/SEI-RMCF_RMCP.pdf?src-supplier=Avnet; ComponentLink5Description: https://www.seielect.com/Catalog/SEI-RMCF_RMCP.pdf?src-supplier=Digikey; ComponentLink5URL: https://www.seielect.com/Catalog/SEI-RMCF_RMCP.pdf?src-supplier=Digikey; Construction: Rectangular; JESD-609 Code: e3; Library Name: Symbols.SchLib; Max Operating Temperature: 155°C; Min Operating Temperature: -55°C; Mounting Technology: Surface Mount; Package Shape: Rectangular; Package Style: SMTMeter; Packaging: Tape and Reel; Pins: 2; Pricing: 1=0.1, 10=0.015, 50=0.009, 100=0.0075, 500=0.00498, 1000=0.00425 (USD); Reach SVHC Compliant: Yes; Resistance: 910k; Resistor Type: FIXED RESISTOR; RoHS: Yes; RoHS Compliant: Yes; Stock: 226824; Supplier: Digikey; Supplier Part Number: RMCF0603FT910KCT-ND; Technology: METAL GLAZE/THICK FILM; Terminal Finish: Nickel; Termination: Wraparound; Tolerance: 1%; Working Voltage: 75V
R4 = RES 0603 43kR 1%: Fixed Resistor, Metal Glaze/thick Film, 0.1W, 43000ohm, 75V, 1% +/-Tol, 100ppm/Cel, Surface Mount, 0603; Manufacturer: Yageo; Manufacturer Part Number: RC0603FR-0743KL; Additional Feature: LASER TRIMMABLE; Package Height: 0.45mm; Package Length: 1.6mm; Package Width: 0.8mm; Power: 100mW; Rated Temperature: 70°C; Temperature Coefficient: 100ppm/°C; Case/Package: 0603; Category: Chip SMD Resistors; Component Kind: Standard; ComponentLink1Description: https://datasheet.ciiva.com:443/pdfs/VipMasterIC/IC/YAGO/YAGO-S-A0008145938/YAGO-S-A0008145938-1.pdf?src-supplier=IHS+Markit; ComponentLink1URL: https://datasheet.ciiva.com:443/pdfs/VipMasterIC/IC/YAGO/YAGO-S-A0008145938/YAGO-S-A0008145938-1.pdf?src-supplier=IHS+Markit; ComponentLink2Description: https://datasheet.ciiva.com/2454/pyu-r-int-thick-7-2454879.pdf?src-supplier=Digikey; ComponentLink2URL: https://datasheet.ciiva.com/2454/pyu-r-int-thick-7-2454879.pdf?src-supplier=Digikey; ComponentLink3Description: https://datasheet.ciiva.com/2454/pyu-r-int-thick-7-2454880.pdf?src-supplier=Digikey; ComponentLink3URL: https://datasheet.ciiva.com/2454/pyu-r-int-thick-7-2454880.pdf?src-supplier=Digikey; ComponentLink4Description: https://datasheet.ciiva.com/2454/pyu-r-int-thick-7-2454881.pdf?src-supplier=Digikey; ComponentLink4URL: https://datasheet.ciiva.com/2454/pyu-r-int-thick-7-2454881.pdf?src-supplier=Digikey; ComponentLink5Description: https://datasheet.ciiva.com/12558/rc0603-272842-12558507.pdf?src-supplier=Mouser; ComponentLink5URL: https://datasheet.ciiva.com/12558/rc0603-272842-12558507.pdf?src-supplier=Mouser; Construction: Chip; JESD-609 Code: e3; Library Name: Symbols.SchLib; Max Operating Temperature: 155°C; Min Operating Temperature: -55°C; Mounting Technology: Surface Mount; Package Shape: Rectangular; Package Style: SMTMeter; Packaging: Tape and Reel; Pins: 2; Pricing: 1=0.1, 10=0.02, 50=0.012, 100=0.0099, 500=0.00662, 1000=0.00565 (USD); Reach SVHC Compliant: Yes; Resistor Type: FIXED RESISTOR; RoHS: Yes; RoHS Compliant: Yes; Stock: 159898; Supplier: Digikey; Supplier Part Number: 311-43.0KHRDKR-ND; Technology: METAL GLAZE/THICK FILM; Terminal Finish: Nickel; Termination: Wraparound; Tolerance: 1%; Value: 43kR; Working Voltage: 75V
R5 = RES 0603 51k 1%: Fixed Resistor, Metal Glaze/thick Film, 0.1W, 51000ohm, 75V, 1% +/-Tol, 100ppm/Cel, Surface Mount, 0603; Manufacturer: Yageo; Manufacturer Part Number: RC0603FR-0751KL; Additional Feature: LASER TRIMMABLE; Package Height: 0.45mm; Package Length: 1.6mm; Package Width: 0.8mm; Power: 100mW; Rated Temperature: 70°C; Temperature Coefficient: 100ppm/°C; Value: 51kR; Case/Package: 0603; Category: Chip SMD Resistors; Component Kind: Standard; ComponentLink1Description: https://datasheet.ciiva.com:443/pdfs/VipMasterIC/IC/YAGO/YAGO-S-A0008145938/YAGO-S-A0008145938-1.pdf?src-supplier=IHS+Markit; ComponentLink1URL: https://datasheet.ciiva.com:443/pdfs/VipMasterIC/IC/YAGO/YAGO-S-A0008145938/YAGO-S-A0008145938-1.pdf?src-supplier=IHS+Markit; ComponentLink2Description: https://datasheet.ciiva.com/11819/0900766b80f96399-11819378.pdf?src-supplier=Rs; ComponentLink2URL: https://datasheet.ciiva.com/11819/0900766b80f96399-11819378.pdf?src-supplier=Rs; ComponentLink3Description: https://datasheet.ciiva.com/2454/pyu-r-int-thick-7-2454124.pdf?src-supplier=Digikey; ComponentLink3URL: https://datasheet.ciiva.com/2454/pyu-r-int-thick-7-2454124.pdf?src-supplier=Digikey; ComponentLink4Description: https://datasheet.ciiva.com/2454/pyu-r-int-thick-7-2454125.pdf?src-supplier=Digikey; ComponentLink4URL: https://datasheet.ciiva.com/2454/pyu-r-int-thick-7-2454125.pdf?src-supplier=Digikey; ComponentLink5Description: https://datasheet.ciiva.com/12558/rc0603-272842-12558554.pdf?src-supplier=Mouser; ComponentLink5URL: https://datasheet.ciiva.com/12558/rc0603-272842-12558554.pdf?src-supplier=Mouser; Construction: Chip; JESD-609 Code: e3; Library Name: Symbols.SchLib; Max Operating Temperature: 155°C; Min Operating Temperature: -55°C; Mounting Technology: Surface Mount; Package Shape: Rectangular; Package Style: SMTMeter; Packaging: Tape and Reel; Pins: 2; Pricing: 1=0.1, 10=0.019, 50=0.0112, 100=0.0093, 500=0.00622, 1000=0.00532 (USD); Reach SVHC Compliant: Yes; Resistance: 51k; Resistor Type: FIXED RESISTOR; RoHS: Yes; RoHS Compliant: Yes; Stock: 1121944; Supplier: Digikey; Supplier Part Number: 311-51.0KHRCT-ND; Technology: METAL GLAZE/THICK FILM; Terminal Finish: Nickel; Termination: Wraparound; Tolerance: 1%; Working Voltage: 75V
R6 = RES 1206 WIDE 15mR 1%: Fixed Resistor, Thin Film, 1W, 0.015ohm, 1% +/-Tol, 200ppm/Cel, Surface Mount, 1206; Manufacturer: Susumu; Manufacturer Part Number: PRL1632-R015-F-T1; Package Height: 0.5mm; Package Length: 3.2mm; Package Width: 1.6mm; Temperature Coefficient: 200ppm/°C; Case/Package: 1206; Category: Chip SMD Resistors; Component Kind: Standard; ComponentLink1Description: https://datasheet.ciiva.com:443/pdfs/VipMasterIC/IC/SUSU/SUSUD00053/SUSUD00053-1.pdf?src-supplier=IHS+Markit; ComponentLink1URL: https://datasheet.ciiva.com:443/pdfs/VipMasterIC/IC/SUSU/SUSUD00053/SUSUD00053-1.pdf?src-supplier=IHS+Markit; ComponentLink2Description: https://datasheet.ciiva.com/2490/prl-rl-data-sheet-2490763.pdf?src-supplier=Digikey; ComponentLink2URL: https://datasheet.ciiva.com/2490/prl-rl-data-sheet-2490763.pdf?src-supplier=Digikey; ComponentLink3Description: https://datasheet.ciiva.com/2490/prl-rl-data-sheet-2490764.pdf?src-supplier=Digikey; ComponentLink3URL: https://datasheet.ciiva.com/2490/prl-rl-data-sheet-2490764.pdf?src-supplier=Digikey; ComponentLink4Description: https://datasheet.ciiva.com/2490/prl-rl-data-sheet-2490765.pdf?src-supplier=Digikey; ComponentLink4URL: https://datasheet.ciiva.com/2490/prl-rl-data-sheet-2490765.pdf?src-supplier=Digikey; Construction: Chip; JESD-609 Code: e3; Library Name: Symbols.SchLib; Mounting Technology: Surface Mount; Package Shape: Rectangular; Package Style: SMTMeter; Packaging: Tape and Reel; Pins: 2; Power: 1W; Pricing: 1=0.61, 10=0.485, 25=0.438, 50=0.3942, 100=0.3256, 250=0.3066, 500=0.2482 (USD); Reach SVHC Compliant: Yes; Resistor Type: FIXED RESISTOR; RoHS: Yes; RoHS Compliant: Yes; Stock: 13129; Supplier: Digikey; Supplier Part Number: PRL1632.015FCT-ND; Technology: THIN FILM; Terminal Finish: Tin; Termination: Wraparound; Tolerance: 1%; Value: 15mR
R7 R9 R10 R13 R17 = RES 0508 2R7 1%: 3430 A2 1% 2R7 150PPM 1K Rl; Manufacturer: CGS; Manufacturer Part Number: 3430A2F2R7TDF; Category: Chip SMD Resistors; Component Kind: Standard; Library Name: Symbols.SchLib; Pricing: 1=0.25, 10=0.13, 50=0.0892, 100=0.0768, 500=0.05638 (USD); RoHS: No; Stock: 1880; Supplier: Digikey; Supplier Part Number: 1712-3430A2F2R7TDFCT-ND; Value: 2R7
R8 = RES 0603 100kR 1%: Fixed Resistor, Metal Glaze/thick Film, 0.1W, 100000ohm, 75V, 1% +/-Tol, 100ppm/Cel, Surface Mount, 0603; Manufacturer: Yageo; Manufacturer Part Number: RC0603FR-07100KL; Additional Feature: LASER TRIMMABLE; Package Height: 0.45mm; Package Length: 1.6mm; Package Width: 0.8mm; Power: 100mW; Rated Temperature: 70°C; Temperature Coefficient: 100ppm/°C; Case/Package: 0603; Category: Chip SMD Resistors; Component Kind: Standard; ComponentLink1Description: https://datasheet.ciiva.com:443/pdfs/VipMasterIC/IC/YAGO/YAGO-S-A0008145938/YAGO-S-A0008145938-1.pdf?src-supplier=IHS+Markit; ComponentLink1URL: https://datasheet.ciiva.com:443/pdfs/VipMasterIC/IC/YAGO/YAGO-S-A0008145938/YAGO-S-A0008145938-1.pdf?src-supplier=IHS+Markit; ComponentLink2Description: https://datasheet.ciiva.com/11808/0900766b80f96399-11808107.pdf?src-supplier=Rs; ComponentLink2URL: https://datasheet.ciiva.com/11808/0900766b80f96399-11808107.pdf?src-supplier=Rs; ComponentLink3Description: https://datasheet.ciiva.com/22747/443971-22747516.pdf?src-supplier=Element14; ComponentLink3URL: https://datasheet.ciiva.com/22747/443971-22747516.pdf?src-supplier=Element14; ComponentLink4Description: https://datasheet.ciiva.com/7746/1738695-7746234.pdf?src-supplier=Element14; ComponentLink4URL: https://datasheet.ciiva.com/7746/1738695-7746234.pdf?src-supplier=Element14; ComponentLink5Description: https://datasheet.ciiva.com/7983/443971-7983593.pdf?src-supplier=Element14; ComponentLink5URL: https://datasheet.ciiva.com/7983/443971-7983593.pdf?src-supplier=Element14; ComponentLink6Description: https://datasheet.ciiva.com/7746/1738695-7746235.pdf?src-supplier=Element14; ComponentLink6URL: https://datasheet.ciiva.com/7746/1738695-7746235.pdf?src-supplier=Element14; ComponentLink7Description: https://datasheet.ciiva.com/46440/1868787-46440889.pdf?src-supplier=Element14; ComponentLink7URL: https://datasheet.ciiva.com/46440/1868787-46440889.pdf?src-supplier=Element14; Construction: Chip; JESD-609 Code: e3; Library Name: Symbols.SchLib; Max Operating Temperature: 155°C; Min Operating Temperature: -55°C; Mounting Technology: Surface Mount; Package Shape: Rectangular; Package Style: SMTMeter; Packaging: Tape and Reel; Pins: 2; Pricing: 1=0.1, 10=0.019, 50=0.0112, 100=0.0093, 500=0.00622, 1000=0.00532, 5000=0.00382, 10000=0.00335, 25000=0.00287, 35000=0.00278, 50000=0.00257, 125000=0.00232 (USD); Reach SVHC Compliant: Yes; Resistor Type: FIXED RESISTOR; RoHS: Yes; RoHS Compliant: Yes; Stock: 2343367; Supplier: Digikey; Supplier Part Number: 311-100KHRCT-ND; Technology: METAL GLAZE/THICK FILM; Terminal Finish: Nickel; Termination: Wraparound; Tolerance: 1%; Value: 100kR; Working Voltage: 75V
R11 = RES 0603 732kR 1%: Fixed Resistor, Metal Glaze/thick Film, 0.1W, 732000ohm, 75V, 1% +/-Tol, 100ppm/Cel, Surface Mount, 0603; Manufacturer: Yageo; Manufacturer Part Number: RC0603FR-07732KL; Additional Feature: LASER TRIMMABLE; Package Height: 0.45mm; Package Length: 1.6mm; Package Width: 0.8mm; Power: 100mW; Rated Temperature: 70°C; Temperature Coefficient: 100ppm/°C; Case/Package: 0603; Category: Chip SMD Resistors; Component Kind: Standard; ComponentLink1Description: https://datasheet.ciiva.com:443/pdfs/VipMasterIC/IC/YAGO/YAGO-S-A0008145938/YAGO-S-A0008145938-1.pdf?src-supplier=IHS+Markit; ComponentLink1URL: https://datasheet.ciiva.com:443/pdfs/VipMasterIC/IC/YAGO/YAGO-S-A0008145938/YAGO-S-A0008145938-1.pdf?src-supplier=IHS+Markit; ComponentLink2Description: https://datasheet.ciiva.com/12575/rc0603-272842-12575925.pdf?src-supplier=Mouser; ComponentLink2URL: https://datasheet.ciiva.com/12575/rc0603-272842-12575925.pdf?src-supplier=Mouser; Construction: Chip; JESD-609 Code: e3; Library Name: Symbols.SchLib; Max Operating Temperature: 155°C; Min Operating Temperature: -55°C; Mounting Technology: Surface Mount; Package Shape: Rectangular; Package Style: SMTMeter; Packaging: Tape and Reel; Pins: 2; Pricing: 1=0.1, 10=0.019, 50=0.0114, 100=0.0094, 500=0.00628, 1000=0.00536 (USD); Reach SVHC Compliant: Yes; Resistor Type: FIXED RESISTOR; RoHS: Yes; RoHS Compliant: Yes; Stock: 51998; Supplier: Digikey; Supplier Part Number: 311-732KHRCT-ND; Technology: METAL GLAZE/THICK FILM; Terminal Finish: Nickel; Termination: Wraparound; Tolerance: 1%; Value: 732kR; Working Voltage: 75V
R12 = RES 0603 91kR 1%: Fixed Resistor, Metal Glaze/thick Film, 0.1W, 91000ohm, 75V, 1% +/-Tol, 100ppm/Cel, Surface Mount, 0603; Manufacturer: Yageo; Manufacturer Part Number: RC0603FR-0791KL; Additional Feature: LASER TRIMMABLE; Package Height: 0.45mm; Package Length: 1.6mm; Package Width: 0.8mm; Power: 100mW; Rated Temperature: 70°C; Temperature Coefficient: 100ppm/°C; Case/Package: 0603; Category: Chip SMD Resistors; Component Kind: Standard; ComponentLink1Description: https://datasheet.ciiva.com:443/pdfs/VipMasterIC/IC/YAGO/YAGO-S-A0008145938/YAGO-S-A0008145938-1.pdf?src-supplier=IHS+Markit; ComponentLink1URL: https://datasheet.ciiva.com:443/pdfs/VipMasterIC/IC/YAGO/YAGO-S-A0008145938/YAGO-S-A0008145938-1.pdf?src-supplier=IHS+Markit; ComponentLink2Description: https://datasheet.ciiva.com/11819/0900766b80c66297-11819716.pdf?src-supplier=Rs; ComponentLink2URL: https://datasheet.ciiva.com/11819/0900766b80c66297-11819716.pdf?src-supplier=Rs; ComponentLink3Description: https://datasheet.ciiva.com/15189/getdatasheetpartid-326529-15189837.pdf?src-supplier=Verical; ComponentLink3URL: https://datasheet.ciiva.com/15189/getdatasheetpartid-326529-15189837.pdf?src-supplier=Verical; Construction: Chip; JESD-609 Code: e3; Library Name: Symbols.SchLib; Max Operating Temperature: 155°C; Min Operating Temperature: -55°C; Mounting Technology: Surface Mount; Package Shape: Rectangular; Package Style: SMTMeter; Packaging: Tape and Reel; Pins: 2; Pricing: 1=0.1, 10=0.019, 50=0.0112, 100=0.0093, 500=0.00622, 1000=0.00532 (USD); Reach SVHC Compliant: Yes; Resistor Type: FIXED RESISTOR; RoHS: Yes; RoHS Compliant: Yes; Stock: 100122; Supplier: Digikey; Supplier Part Number: 311-91.0KHRCT-ND; Technology: METAL GLAZE/THICK FILM; Terminal Finish: Nickel; Termination: Wraparound; Tolerance: 1%; Value: 91kR; Working Voltage: 75V
R14 = THERM NTC 100kR: NTC Thermistor, 100000ohm, Surface Mount; Manufacturer: Vishay BCcomponents; Manufacturer Part Number: NTCS0603E3104FXT; Power: 125mW; Rated Temperature: 25°C; Thermistor Application: OVERLOAD PROTECTION; Tolerance: 1%; Category: Thermistors; Component Kind: Standard; ComponentLink1Description: https://datasheet.ciiva.com:443/pdfs/VipMasterIC/IC/BEYS/BEYSS04817/BEYSS04817-1.pdf?src-supplier=IHS+Markit; ComponentLink1URL: https://datasheet.ciiva.com:443/pdfs/VipMasterIC/IC/BEYS/BEYSS04817/BEYSS04817-1.pdf?src-supplier=IHS+Markit; ComponentLink2Description: https://datasheet.ciiva.com/11822/0900766b80d9a0b4-11822175.pdf?src-supplier=Rs; ComponentLink2URL: https://datasheet.ciiva.com/11822/0900766b80d9a0b4-11822175.pdf?src-supplier=Rs; ComponentLink3Description: https://datasheet.ciiva.com/15098/getdatasheetpartid-708018-15098085.pdf?src-supplier=Verical; ComponentLink3URL: https://datasheet.ciiva.com/15098/getdatasheetpartid-708018-15098085.pdf?src-supplier=Verical; ComponentLink4Description: https://datasheet.ciiva.com/22712/459646-22712047.pdf?src-supplier=Element14; ComponentLink4URL: https://datasheet.ciiva.com/22712/459646-22712047.pdf?src-supplier=Element14; ComponentLink5Description: https://datasheet.ciiva.com/2848/ntcs0603-2848820.pdf?src-supplier=Digikey; ComponentLink5URL: https://datasheet.ciiva.com/2848/ntcs0603-2848820.pdf?src-supplier=Digikey; ComponentLink6Description: https://datasheet.ciiva.com/2848/ntcs0603-2848821.pdf?src-supplier=Digikey; ComponentLink6URL: https://datasheet.ciiva.com/2848/ntcs0603-2848821.pdf?src-supplier=Digikey; ComponentLink7Description: https://datasheet.ciiva.com/2848/ntcs0603-2848822.pdf?src-supplier=Digikey; ComponentLink7URL: https://datasheet.ciiva.com/2848/ntcs0603-2848822.pdf?src-supplier=Digikey; JESD-609 Code: e3; Library Name: Symbols.SchLib; Manufacturer Series: NTCS0603E3104FXT; Max Operating Temperature: 150°C; Min Operating Temperature: -40°C; Mounting Technology: Surface Mount; Package Shape: Rectangular; Packaging: Tape and Reel; Pins: 2; Pricing: 1=1.04, 10=0.799, 25=0.688, 50=0.6636, 100=0.5652, 500=0.49152, 1000=0.43008 (USD); Reach SVHC Compliant: Yes; Resistor Type: NTC THERMISTOR; RoHS: Yes; RoHS Compliant: Yes; Stock: 29458; Supplier: Digikey; Supplier Part Number: BC2475CT-ND; Terminal Finish: Tin; Terminal Placement: Dual Ended; Termination: Wraparound; Value: 100kR
